# Altium schematic sheet Relay Driver.SchDoc("Relay Driver")
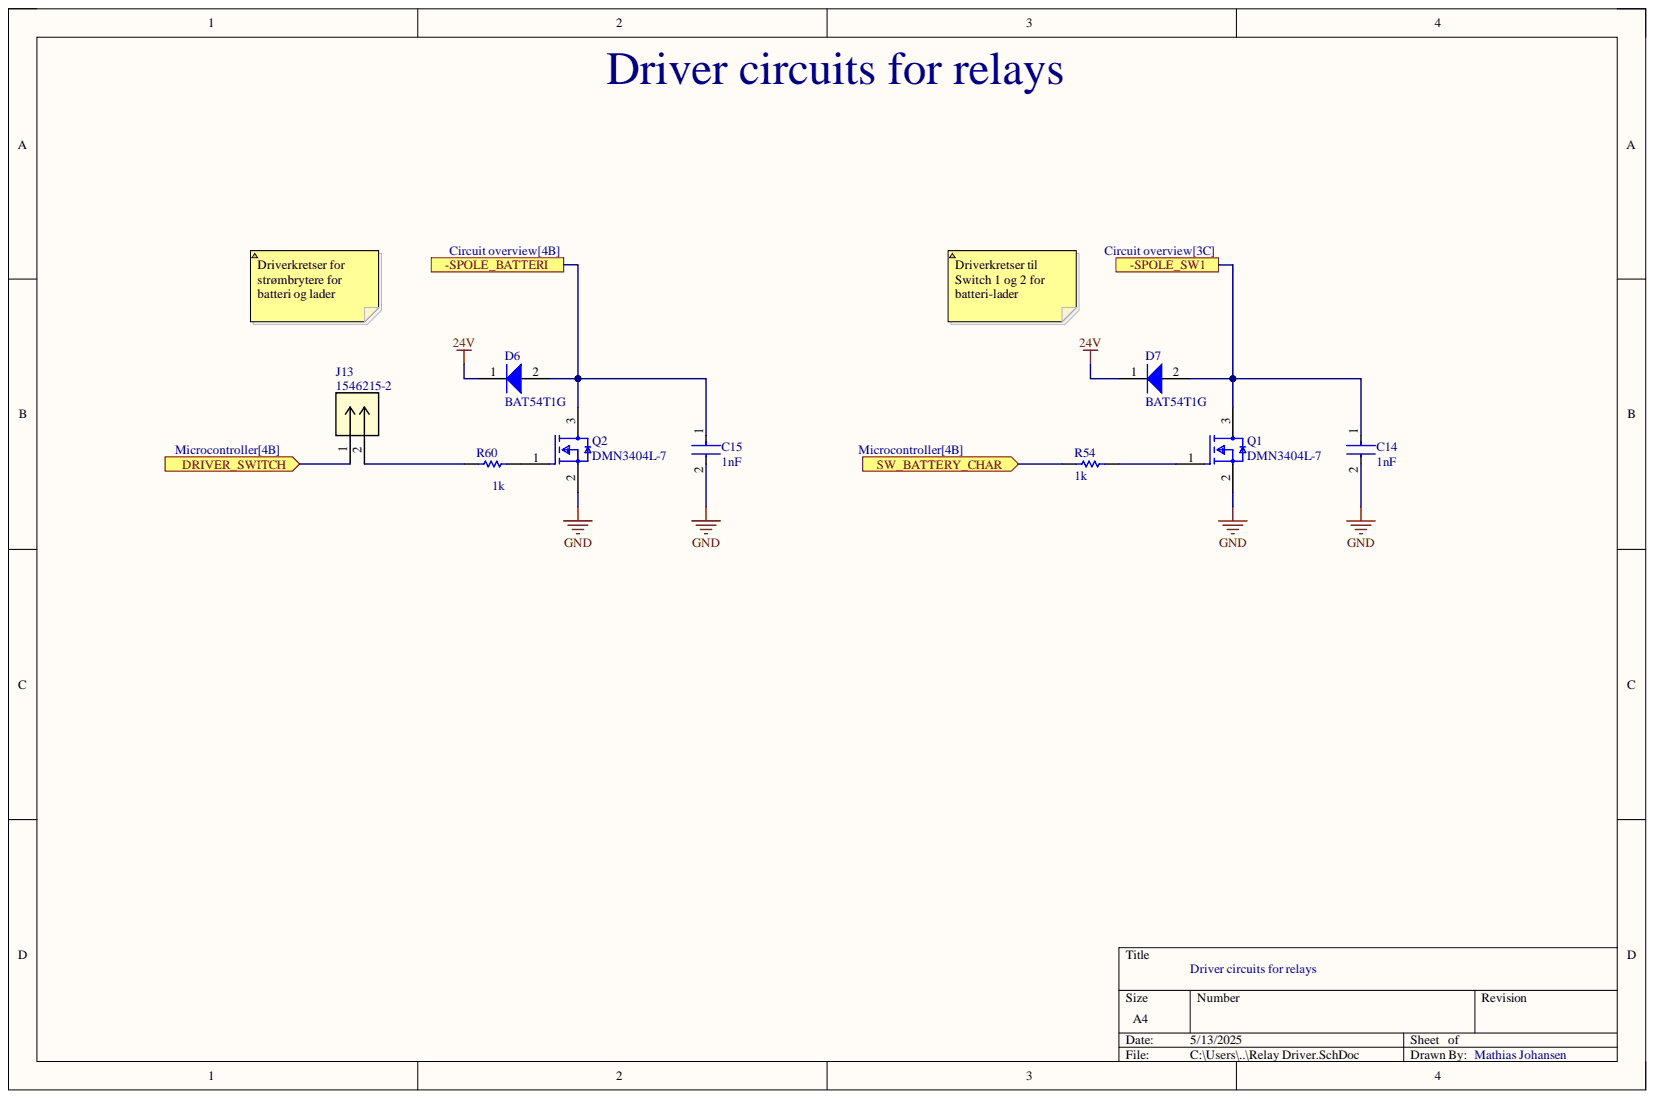
[diagram p0: Relay Driver.SchDoc]

## Parts
C14  1nF  [FP-CL10-IPC_C]
C15  1nF  [FP-CL10-IPC_C]
D6  BAT54T1G  [ONSC-SOD-123-2-425-04_V]
D7  BAT54T1G  [ONSC-SOD-123-2-425-04_V]
J13  1546215-2  [CONN_1546215-2_TEC]
Q1  DMN3404L-7  [FP-SOT23-MFG]
Q2  DMN3404L-7  [FP-SOT23-MFG]
R54  1k  [RESC2013X60X35ML10T20]
R60  1k  [RESC2013X60X35ML10T20]

## Ports
-SPOLE_BATTERI, -SPOLE_SW1, DRIVER_SWITCH, SW_BATTERY_CHAR

## Nets
24V: D6.1 D7.1
GND: C14.2 C15.2 Q1.2 Q2.2
-SPOLE_BATTERI: C15.1 D6.2 Q2.3 ; port -SPOLE_BATTERI
-SPOLE_SW1: C14.1 D7.2 Q1.3 ; port -SPOLE_SW1
DRIVER_SWITCH: J13.1 ; port DRIVER_SWITCH
SW_BATTERY_CHAR: R54.1 ; port SW_BATTERY_CHAR
NetJ13_2: J13.2 R60.1
NetQ1_1: Q1.1 R54.2
NetQ2_1: Q2.1 R60.2

## Library data of the parts
C14 C15 = CMP-13271-003275-1: Cap Ceramic 1nF 100V X7R ±10% Pad SMD 0603 +125°C T/R; Capacitance: 1nF; Case Code (Imperial): 0603; Case Code (Metric): 1608; Case/Package: 0603; Component Kind: Standard; Contact Plating: Tin; Depth: 0.8mm; Dielectric: X7R; Height: 0.8mm; Lead Free: Lead Free; Length: 1.6mm; Library Name: Altium Content Vault; Material: Ceramic; Max Operating Temperature: 125°C; Min Operating Temperature: -55°C; Mount: Surface Mount; Package Quantity: 1; Packaging: Tape and Reel; Radiation Hardening: No; Thickness: 0.035inch; Tolerance: 10%; Voltage: 100V; Voltage Rating: 100V; Voltage Rating (DC): 100V; Width: 0.031inch
D6 D7 = CMP-1055-00429-1: Schottky Barrier Diode, 2-Pin SOD-123, Pb-Free, Tape and Reel; Manufacturer: On Semiconductor; Configuration: Single; IFSM Max (A): 0.6; trr Max (ns): 5; Component Kind: Standard; ComponentLink1Description: Manufacturer URL; ComponentLink1URL: http://www.onsemi.com/; ComponentLink2Description: Datasheet; ComponentLink2URL: http://www.onsemi.cn/pub_link/Collateral/BAT54T1-D.PDF; ComponentLink3Description: Package Specification; ComponentLink3URL: http://www.onsemi.com/pub_link/Collateral/425-04.PDF; DatasheetVersion: Rev. 9; Library Name: Altium Content Vault; Mounting Technology: Surface Mount; PackageDescription: 2-Pin SOD, Body 1.6 x 2.69 mm; PackageReference: SOD-123-2-425-04; PackageVersion: Rev. G, 10/2009; Packing: Tape and Reel; PartNumber: BAT54T1G; RoHS: TRUE
J13 = 1546215-2: No Description Available; Mfr_Name: TE Connectivity; Component Kind: Standard; Library Name: 1546215-2.IntLib; RefDes: RefDes; Type: Type
Q1 Q2 = CMP-12801-000054-1: MOSFET N-CH 30V 5.8A SOT-23; Manufacturer: Diodes Inc.; Automotive Grade: NA; Continuous Drain Current: 4.2A; Depletion Mode: False; Drain To Source Resistance: 24mΩ; Gate Charge At Vgs: 11.3nC @ 10V; Gate To Source Voltage: 20V; Input Capacitace At Vds: 498pF @ 15V; Max Forward Diode Voltage: 1V; Max Junction Temp: +150°C; Number Of Channels: 1; Power Dissipation: 0.72W; Pulse Drain Current: 30A; Rthja Max: 173°C/W; Standoff Height: 0.013mm; Threshold Vgs Max: 2V; Turn Off Delay Time: 13.92ns; Turn On Delay Time: 3.41ns; Typ Forward Diode Voltage: 0.75V; Automotive: No; Category: Trans; Component Kind: Standard; ComponentLink2Description: Datasheet; ComponentLink2URL: https://www.diodes.com/assets/Datasheets/ds31787.pdf; Device Class L1: Discrete Semiconductors; Device Class L2: Transistors; Device Class L3: MOSFETs; Digikey Description: MOSFET N-CH 30V 5.8A SOT-23; Drain To Source Breakdown Voltage: 30V; Drain To Source Voltage: 30V; Footprint Url: https://www.diodes.com/assets/Package-Files/SOT23.pdf; Height: 1.1mm; Lead Free: Yes; Library Name: Altium Content Vault; Manufacturer Part Number: DMN3404L-7; Mouser Description: N-Channel 30 V 28 mOhm Surface Mount Enhancement Mode Mosfet - SOT-23-3; Number Of N Channels: 1; Octopart: https://octopart.com/dmn3404l-7-diodes+inc.-12409285; Package: SOT23; RoHS: TRUE; Temperature: -55°C to +150°C; Temperature Range High: +150°C; Temperature Range Low: -55°C; Threshold Vgs Min: 1V
R54 R60 = CMP-2100-03671-1: Chip Resistor, 1 KOhm, +/- 1%, 125 mW, -55 to 155 degC, 0805 (2012 Metric), RoHS, Tape and Reel; Manufacturer: Yageo; Max Power Dissipation: 125mW; Resistance: 1KOhm; Temperature Coefficient: 100ppm/degreesC; Voltage Rating: 150V; Case Code (Imperial): 0805; Case Code (Metric): 2012; Case/Package: 0805; Component Kind: Standard; Composition: ThickFilm; Datasheet URL: http://www.yageo.com/NewPortal/yageodocoutput?fileName=/pdf/R-Chip/PYu-RC_Group_51_RoHS_L_5.pdf; Datasheet Version: Rev. 5, 10/2016; Depth: 1.25mm; Height: 0.024inch; Lead Free: LeadFree; Length: 0.079inch; Library Name: Altium Content Vault; Manufacturer URL: http://www.yageo.com/; Max Operating Temperature: 155degC; Military Standard: Not; Min Operating Temperature: -55degC; Number of Pins: 2; Number of Terminations: 2; Package Description: 2-Pin Surface Mounted Device, Body 2 x 1.25 mm; Package Quantity: 1; Package Reference: 0805; Packaging: TapeandReel; Power Rating: 125mW; REACH SVHC: Unknown; RoHSCompliant: true; Termination: SMD/SMT; Tolerance: 1%; Voltage Rating (DC): 150V; Width: 0.049inch
